# Altium schematic sheet 10-RF_WIFI.SchDoc(10-RF_WIFI)
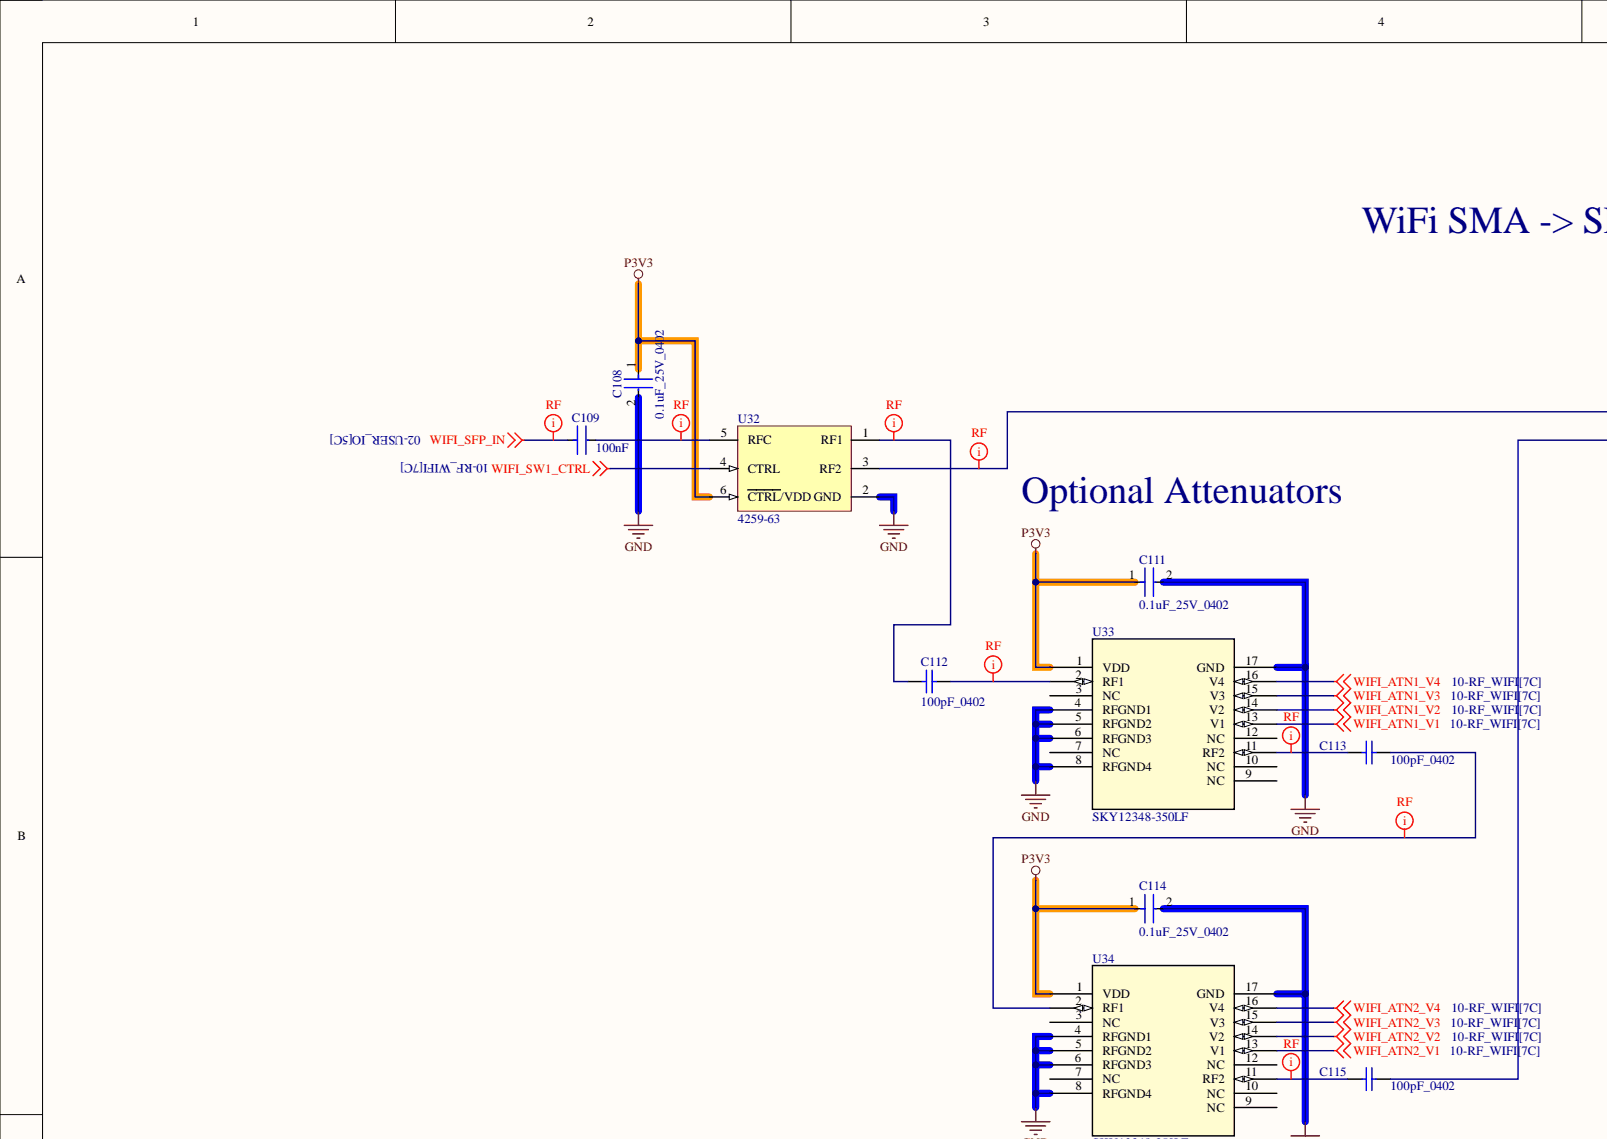
[diagram p0: 10-RF_WIFI.SchDoc, top left]
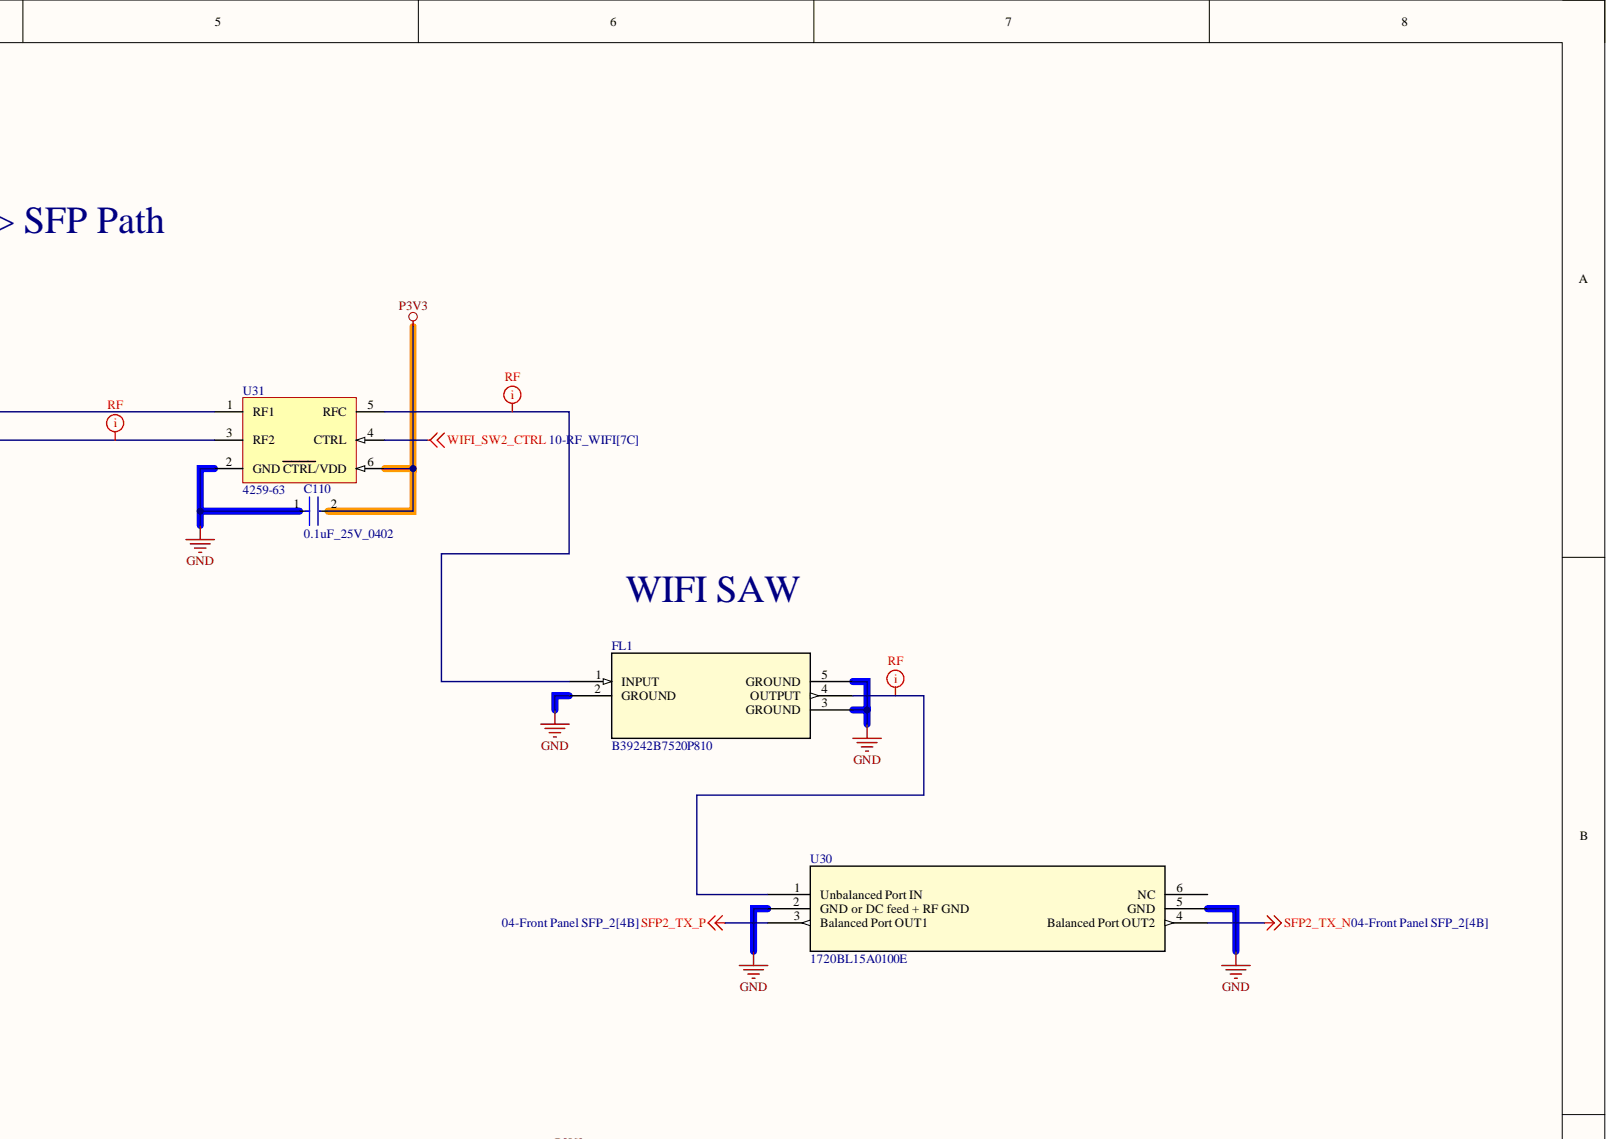
[diagram p1: 10-RF_WIFI.SchDoc, top right]
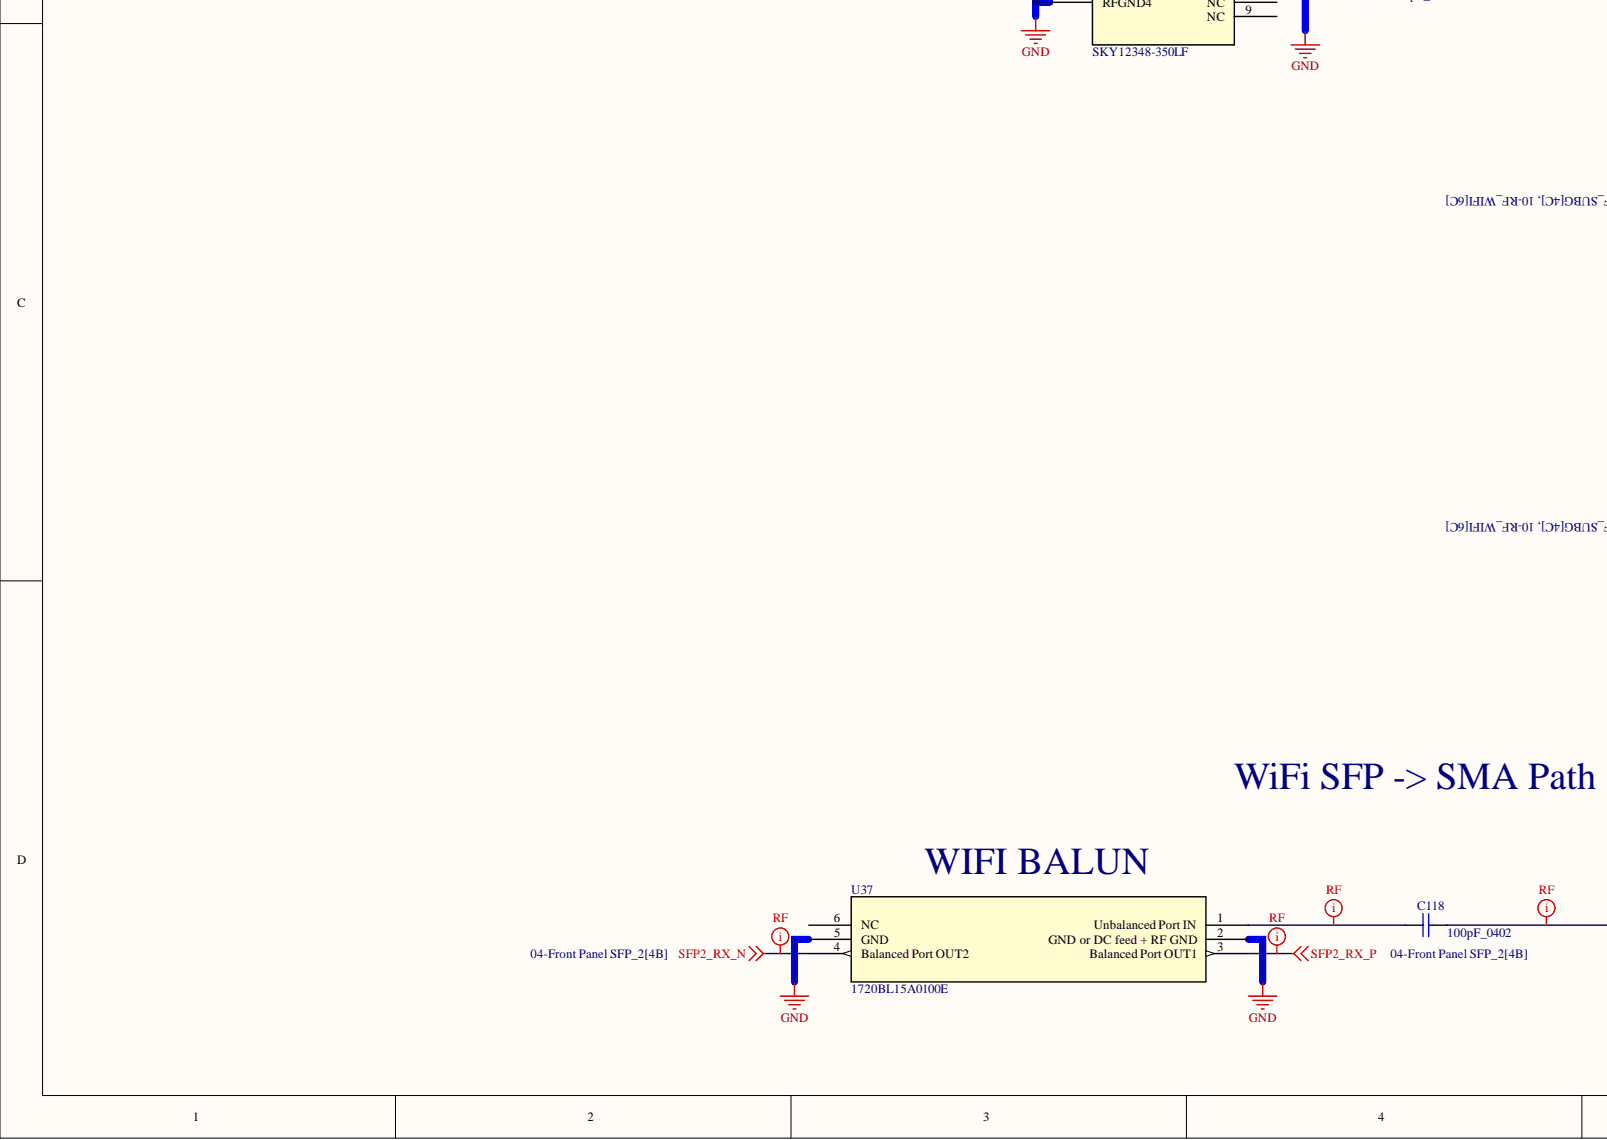
[diagram p2: 10-RF_WIFI.SchDoc, bottom left]
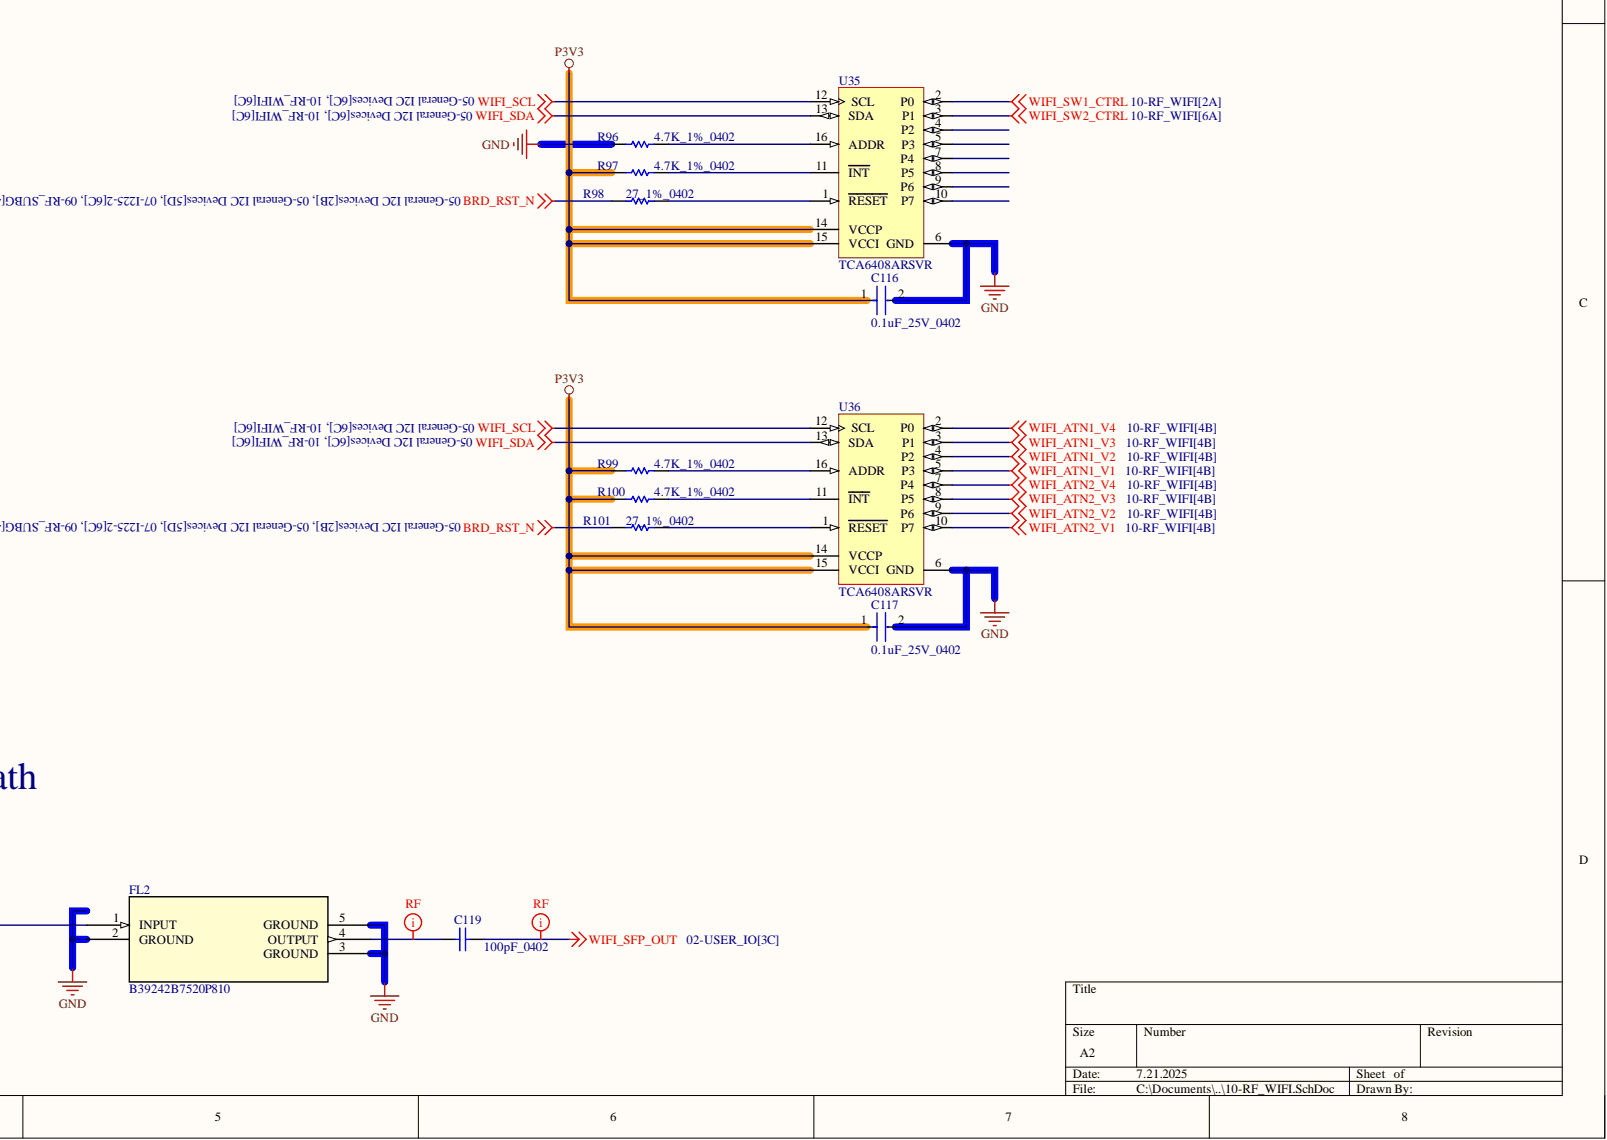
[diagram p3: 10-RF_WIFI.SchDoc, bottom right]

## Parts
C108  0.1uF_25V_0402  [FP-0402-L_1_0_1-W_0_5_0_1-IPC_C]
C109  100nF  [FP-0402-L_1_0_0_05-W_0_5-IPC_B]
C110  0.1uF_25V_0402  [FP-0402-L_1_0_1-W_0_5_0_1-IPC_C]
C111  0.1uF_25V_0402  [FP-0402-L_1_0_1-W_0_5_0_1-IPC_C]
C112  100pF_0402  [CAPC1005X55X25ML05T10]
C113  100pF_0402  [CAPC1005X55X25ML05T10]
C114  0.1uF_25V_0402  [FP-0402-L_1_0_1-W_0_5_0_1-IPC_C]
C115  100pF_0402  [CAPC1005X55X25ML05T10]
C116  0.1uF_25V_0402  [FP-0402-L_1_0_1-W_0_5_0_1-IPC_C]
C117  0.1uF_25V_0402  [FP-0402-L_1_0_1-W_0_5_0_1-IPC_C]
C118  100pF_0402  [CAPC1005X55X25ML05T10]
C119  100pF_0402  [CAPC1005X55X25ML05T10]
FL1  B39242B7520P810  [SMD5_SAW-B7520_QUA]
FL2  B39242B7520P810  [SMD5_SAW-B7520_QUA]
R96  4.7K_1%_0402  [RESC1005X40X25NL10T10]
R97  4.7K_1%_0402  [RESC1005X40X25NL10T10]
R98  27_1%_0402  [RESC1005X40X25NL05T05]
R99  4.7K_1%_0402  [RESC1005X40X25NL10T10]
R100  4.7K_1%_0402  [RESC1005X40X25NL10T10]
R101  27_1%_0402  [RESC1005X40X25NL05T05]
U30  1720BL15A0100E  [SMT_15B0050E_JOT]
U31  4259-63  [PERE-SC-70-6_M]
U32  4259-63  [PERE-SC-70-6_M]
U33  SKY12348-350LF  [SKY12348-350LF_SKY]
U34  SKY12348-350LF  [SKY12348-350LF_SKY]
U35  TCA6408ARSVR  [RSV0016A_V]
U36  TCA6408ARSVR  [RSV0016A_V]
U37  1720BL15A0100E  [SMT_15B0050E_JOT]

## Nets
BRD_RST_N: R98.1 R101.1
GND: C108.2 C110.1 C111.2 C114.2 C116.2 C117.2 FL1.2(GROUND) FL1.3(GROUND) FL1.5(GROUND) FL2.2(GROUND) FL2.3(GROUND) FL2.5(GROUND) R96.2 U30.2(GND_or_DC_feed_+_RF_GND) U30.5(GND) U31.2(GND) U32.2(GND) U33.4(RFGND1) U33.5(RFGND2) U33.6(RFGND3) U33.8(RFGND4) U33.17(GND) U34.4(RFGND1) U34.5(RFGND2) U34.6(RFGND3) U34.8(RFGND4) U34.17(GND) U35.6(GND) U36.6(GND) U37.2(GND_or_DC_feed_+_RF_GND) U37.5(GND)
P3V3: C108.1 C110.2 C111.1 C114.1 C116.1 C117.1 R97.2 R99.2 R100.2 U31.6(CTRL/VDD) U32.6(CTRL/VDD) U33.1(VDD) U34.1(VDD) U35.14(VCCP) U35.15(VCCI) U36.14(VCCP) U36.15(VCCI)
SFP2_RX_N: U37.4(Balanced_Port_OUT2)
SFP2_RX_P: U37.3(Balanced_Port_OUT1)
SFP2_TX_N: U30.4(Balanced_Port_OUT2)
SFP2_TX_P: U30.3(Balanced_Port_OUT1)
WIFI_ATN1_V1: U33.13(V1) U36.5(P3)
WIFI_ATN1_V2: U33.14(V2) U36.4(P2)
WIFI_ATN1_V3: U33.15(V3) U36.3(P1)
WIFI_ATN1_V4: U33.16(V4) U36.2(P0)
WIFI_ATN2_V1: U34.13(V1) U36.10(P7)
WIFI_ATN2_V2: U34.14(V2) U36.9(P6)
WIFI_ATN2_V3: U34.15(V3) U36.8(P5)
WIFI_ATN2_V4: U34.16(V4) U36.7(P4)
WIFI_SCL: U35.12(SCL) U36.12(SCL)
WIFI_SDA: U35.13(SDA) U36.13(SDA)
WIFI_SFP_IN: C109.1
WIFI_SFP_OUT: C119.2
WIFI_SW1_CTRL: U32.4(CTRL) U35.2(P0)
WIFI_SW2_CTRL: U31.4(CTRL) U35.3(P1)
NetC109_2: C109.2 U32.5(RFC)
NetC112_1: C112.1 U32.1(RF1)
NetC112_2: C112.2 U33.2(RF1)
NetC113_1: C113.1 U33.11(RF2)
NetC113_2: C113.2 U34.2(RF1)
NetC115_1: C115.1 U34.11(RF2)
NetC115_2: C115.2 U31.3(RF2)
NetC118_1: C118.1 U37.1(Unbalanced_Port_IN)
NetC118_2: C118.2 FL2.1(INPUT)
NetC119_1: C119.1 FL2.4(OUTPUT)
NetFL1_1: FL1.1(INPUT) U31.5(RFC)
NetFL1_4: FL1.4(OUTPUT) U30.1(Unbalanced_Port_IN)
NetR96_1: R96.1 U35.16(ADDR)
NetR97_1: R97.1 U35.11(INT)
NetR98_2: R98.2 U35.1(RESET)
NetR99_1: R99.1 U36.16(ADDR)
NetR100_1: R100.1 U36.11(INT)
NetR101_2: R101.2 U36.1(RESET)
NetU31_1: U31.1(RF1) U32.3(RF2)

Not connected: U30 6(NC); U33 3(NC) 7(NC) 9(NC) 10(NC) 12(NC); U34 3(NC) 7(NC) 9(NC) 10(NC) 12(NC); U35 4(P2) 5(P3) 7(P4) 8(P5) 9(P6) 10(P7); U37 6(NC)

## Library data of the parts
C108 C110 C111 C114 C116 C117 = CMP-2008-02519-2: General Purpose Ceramic Capacitor, 0402, 100nF, 10%, X7R, 15%, 25V; Capacitance: 100nF; Case Code (Imperial): 0402; Case Code (Metric): 1005; Case/Package: 0402; Component Kind: Standard; Construction: Flat; Depth: 0.5mm; Dielectric: X7R; Lead Free: Lead Free; Length: 1mm; Library Name: Altium Content Vault; Max Operating Temperature: 125°C; Min Operating Temperature: -55°C; Mount: Surface Mount; Number of Pins: 2; Package Quantity: 1; Packaging: Tape and Reel; Radiation Hardening: No; REACH SVHC: No SVHC; RoHS Compliant: Yes; Series: -; Termination: SMD/SMT; Thickness: 0.022inch; Tolerance: 10%; Voltage: 25V; Voltage Rating: 25V; Voltage Rating (DC): 25V; Width: 0.02inch
C109 = CMP-14477-000330-2: None; Dielectric: X5R; Tolerance: 10%; Voltage Rating: 50V; Capacitance: 100nF; Case Code (Imperial): 0402; Case Code (Metric): 1005; Case/Package: 0402; Component Kind: Standard; Halogen Free: Halogen Free; Height: 0.5mm; Length: 0.039inch; Library Name: Altium Content Vault; Max Operating Temperature: 85°C; Min Operating Temperature: -55°C; Mount: Surface Mount; Package Quantity: 10000; Packaging: Tape and Reel; RoHS Compliant: Yes; Simulation: UMK105BJ104_V-F; Termination: SMD/SMT; Thickness: 0.022inch; Voltage Rating (DC): 50V; Width: 0.02inch
C112 C113 C115 C118 C119 = CMP-2008-03915-1: Manufacturer: Murata; Part Number: GRM1555C1H101JA01D; Component Kind: Standard; Library Name: Altium Content Vault
FL1 FL2 = B39242B7520P810: No Description Available; Mfr_Name: Qualcomm; Component Kind: Standard; Library Name: B39242B7520P810.SchLib; RefDes: RefDes; Type: SAW Filters
R96 R97 R99 R100 = CMP-2002-05217-1: Manufacturer: Bourns; Part Number: CR0402-FX-4701GLF; Component Kind: Standard; Library Name: Altium Content Vault
R98 R101 = CMP-2002-08434-1: Manufacturer: Vishay Dale; Part Number: CRCW040227R0FKED; Component Kind: Standard; Library Name: Altium Content Vault
U30 U37 = 1720BL15A0100E: No Description Available; Mfr_Name: Johanson Technology; Component Kind: Standard; Library Name: 1720BL15A0100E.SchLib; RefDes: RefDes; Type: Type
U31 U32 = CMP-2000-05298-1: IC RF Switch SPDT, 50 Ohm, 6-Pin SC70, RoHS, Tape and Reel; Manufacturer: Peregrine Semiconductor; Frequency - Lower: 10MHz; Frequency - Upper: 3GHz; IIP3: 55dBm(typ); Impedance: 50Ohm; Insertion Loss @ Frequency: 0.5dB@2GHz; Isolation @ Frequency: 20dB@2GHz(typ); Online Catalog: PE4259; Other Names: 1046-1011-24259-63-ND425963PE4259PE4259SCBC-ZPE4259SCBC-Z-ND; P1dB: 29dBm(typ)IP1dB; RF Type: GeneralPurpose; Topology: Reflective; Voltage - Supply: 1.8V~3.3V; Category: RF/IFandRFID; Circuit: SPDT; Component Kind: Standard; Datasheet URL: https://datasheet.ciiva.com/15100/getdatasheetpartid-685660-15100501.pdf; Datasheet Version: Jan-11; Family: RFSwitches; Features: Single/DualLineControl; Library Name: Altium Content Vault; Manufacturer URL: http://www.psemi.com/; Operating Temperature: -40degC~85degC; Package / Case: 6-TSSOP,SC-88,SOT-363; Package Description: 6-Pin Small Outline Transistor, Body 2.05 x 1.25 mm, Pitch 0.65 mm; Package Reference: SC-70-6; Packaging: Tape&Reel(TR); Series: UltraCMOS; Standard Package: 3,000; Supplier Device Package: SC-70-6
U33 U34 = SKY12348-350LF: No Description Available; Mfr_Name: Skyworks; Component Kind: Standard; Library Name: SKY12348-350LF.SchLib; RefDes: RefDes; Type: Type
U35 U36 = CMP-0314-00021-2: Low-Voltage 8-Bit I2C and SMBus I/O Expander, 1.65 to 5.5 V, -40 to 85 degC, 16-pin UQFN (RSV), Green (RoHS & no Sb/Br); Manufacturer: Texas Instruments; 5V Tolerant I/O: Yes; # of I/Os: 8; fSCLK(Max)(MHz): 400; I2C Address: 0100 00x; SubFamily: I2C IO Expander; VCC(Max)(V): 5.5; VCC(Min)(V): 1.65; Code_JEDEC: MO-288*; Component Kind: Standard; ComponentLink1Description: Datasheet; ComponentLink1URL: http://www.ti.com/general/docs/lit/getliterature.tsp?genericPartNumber=TCA6408A&fileType=pdf; ComponentLink2Description: Package Specification; ComponentLink2URL: http://www.ti.com/litv/pdf/mpqf115g; Configuration Registers: Yes; DatasheetVersion: SCPS192C; Eco-Plan: Green (RoHS & no Sb/Br); Interrupt Output: Yes; Library Name: Altium Content Vault; Low Power: Yes; Max Frequency(kHz): 400; Mounting Technology: Surface Mount; No. of Outputs: 8; PackageDescription: 16-Leads Plastic Quad Flatpack No-Lead, 2.6 x 1.8 x 0.5 mm Body, 0.4 mm Pitch; PackageReference: RSV0016A; PackageVersion: revD, Dec-2011; PartNumber: TCA6408ARSVR; Push-Pull I/O Type: Yes; Reset Input: Yes; Vcc range(V): 1.65 to 5.5
